# Altium schematic sheet Headlight_Right_Board.SchDoc
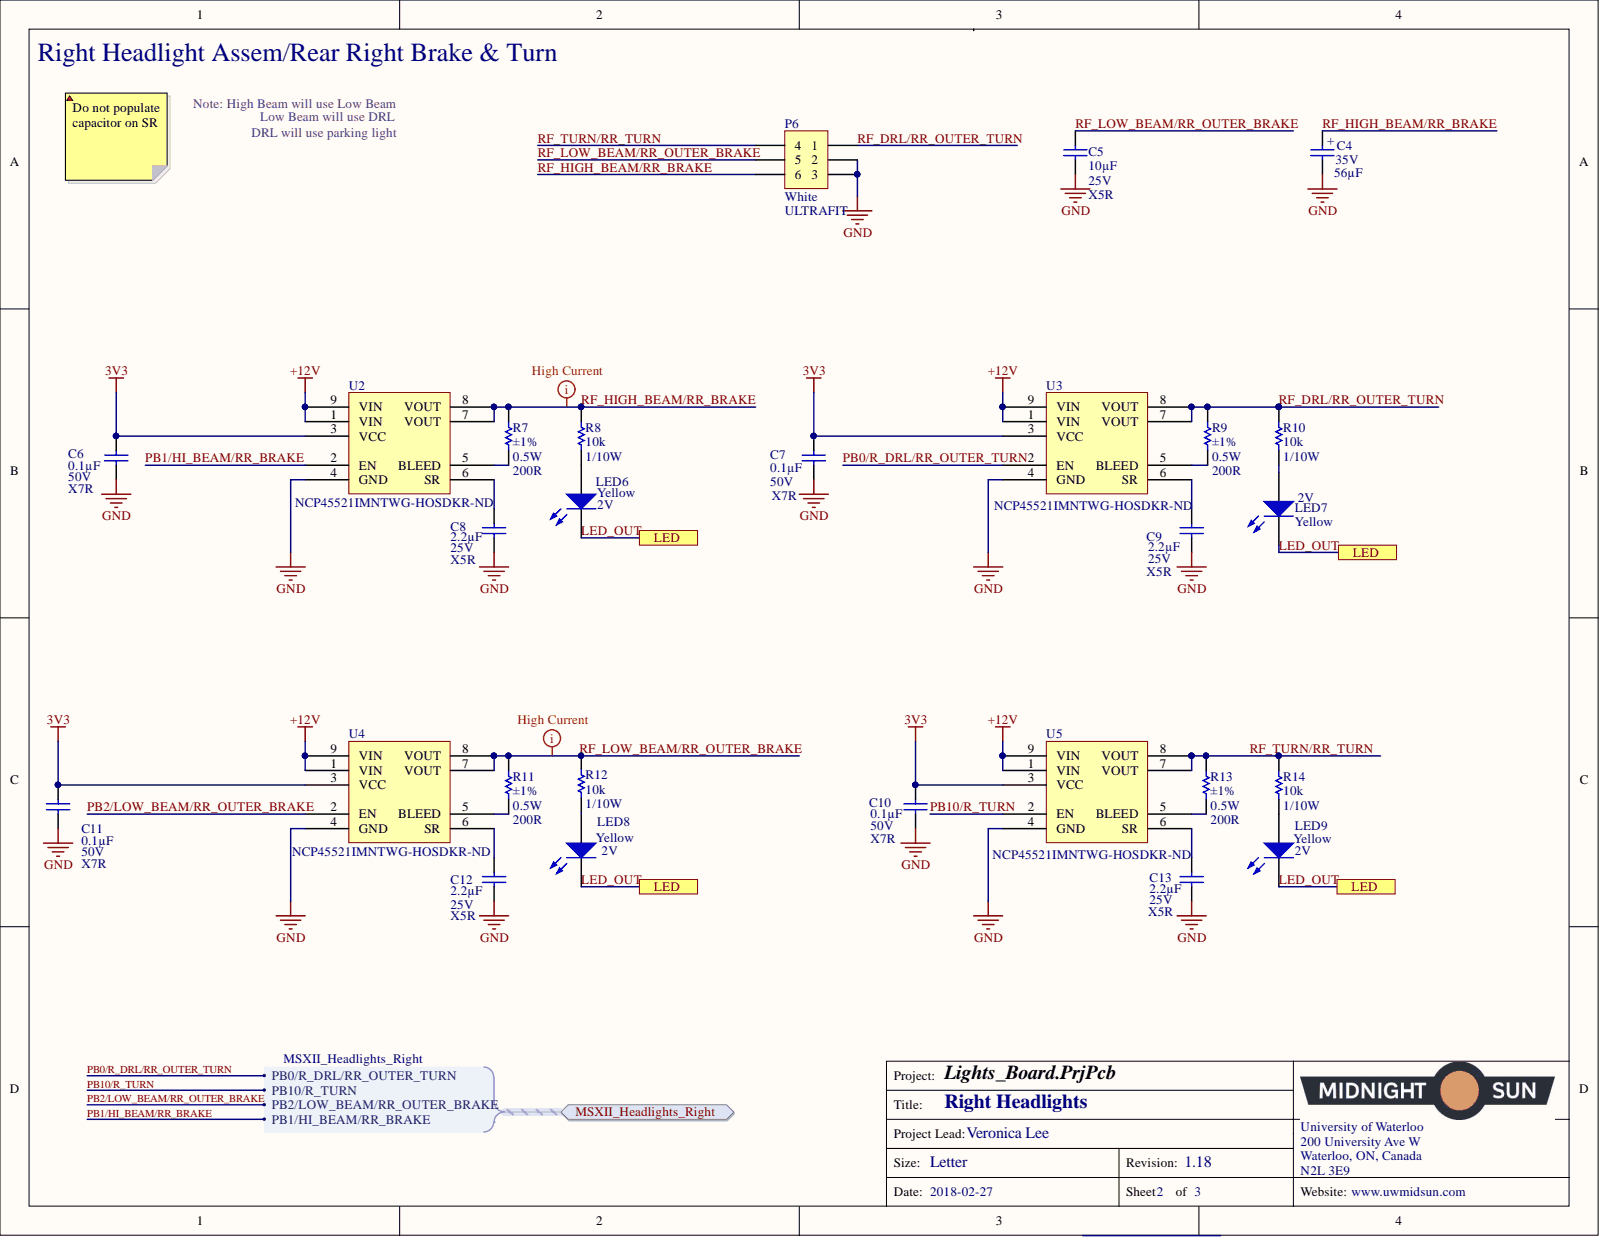
[diagram p0: Headlight_Right_Board.SchDoc]

## Parts
C4  Capacitance: 56µF  Voltage: 35V  [CAP ALUM 56UF 20% 35V RADIAL]  (hidden comment: CAP ALUM 56UF 20% 35V RADIAL)
C5  Capacitance: 10µF  Temperature Coefficient: X5R  Voltage: 25V  [CAP, 0603]  (hidden comment: CAP CER 10uF 25V 20% X5R 0603)
C6  Capacitance: 0.1µF  Temperature Coefficient: X7R  Voltage: 50V  [CAP, 0603]  (hidden comment: CAP CER 0.1UF 50V 10% X7R 0603)
C7  Capacitance: 0.1µF  Temperature Coefficient: X7R  Voltage: 50V  [CAP, 0603]  (hidden comment: CAP CER 0.1UF 50V 10% X7R 0603)
C8  Capacitance: 2.2µF  Temperature Coefficient: X5R  Voltage: 25V  [CAP, 0603]  (hidden comment: CAP CER 2.2UF 25V 10% X5R 0603)  (no BOM)
C9  Capacitance: 2.2µF  Temperature Coefficient: X5R  Voltage: 25V  [CAP, 0603]  (hidden comment: CAP CER 2.2UF 25V 10% X5R 0603)  (no BOM)
C10  Capacitance: 0.1µF  Temperature Coefficient: X7R  Voltage: 50V  [CAP, 0603]  (hidden comment: CAP CER 0.1UF 50V 10% X7R 0603)
C11  Capacitance: 0.1µF  Voltage: 50V  [CAP, 0603]  (hidden comment: CAP CER 0.1UF 50V 10% X7R 0603)
C12  Capacitance: 2.2µF  Temperature Coefficient: X5R  Voltage: 25V  [CAP, 0603]  (hidden comment: CAP CER 2.2UF 25V 10% X5R 0603)  (no BOM)
C13  Capacitance: 2.2µF  Temperature Coefficient: X5R  Voltage: 25V  [CAP, 0603]  (hidden comment: CAP CER 2.2UF 25V 10% X5R 0603)  (no BOM)
LED6  Color: Yellow  Voltage - Forward (Vf) (Typ): 2V  [LED_0603]  (hidden comment: LED YELLOW CLEAR 2.1V 0603)
LED7  Color: Yellow  Voltage - Forward (Vf) (Typ): 2V  [LED_0603]  (hidden comment: LED YELLOW CLEAR 2.1V 0603)
LED8  Color: Yellow  Voltage - Forward (Vf) (Typ): 2V  [LED_0603]  (hidden comment: LED YELLOW CLEAR 2.1V 0603)
LED9  Color: Yellow  Voltage - Forward (Vf) (Typ): 2V  [LED_0603]  (hidden comment: LED YELLOW CLEAR 2.1V 0603)
P6  Insulation Color: White  Series: ULTRAFIT  [CONN, 6POS ULTRA-FIT - NATURAL COLOR]  (hidden comment: CONN 6POS ULTRA-FIT NATURAL COLOR 0.138")
R7  value 200R  Power (Watts): 0.5W  Tolerance: ±1%  [RES 0805]  (hidden comment: RES SMD 200 OHM 1% 1/2W 0805)
R8  Power: 1/10W  Resistance: 10k  [RES, 0603]  (hidden comment: RES 10K OHM 1% 1/10W 0603)
R9  value 200R  Power (Watts): 0.5W  Tolerance: ±1%  [RES 0805]  (hidden comment: RES SMD 200 OHM 1% 1/2W 0805)
R10  Power: 1/10W  Resistance: 10k  [RES, 0603]  (hidden comment: RES 10K OHM 1% 1/10W 0603)
R11  value 200R  Power (Watts): 0.5W  Tolerance: ±1%  [RES 0805]  (hidden comment: RES SMD 200 OHM 1% 1/2W 0805)
R12  Power: 1/10W  Resistance: 10k  [RES, 0603]  (hidden comment: RES 10K OHM 1% 1/10W 0603)
R13  value 200R  Power (Watts): 0.5W  Tolerance: ±1%  [RES 0805]  (hidden comment: RES SMD 200 OHM 1% 1/2W 0805)
R14  Power: 1/10W  Resistance: 10k  [RES, 0603]  (hidden comment: RES 10K OHM 1% 1/10W 0603)
U2  IC LOAD SWITCH ACT-HI 10.5A 8DFN  [IC LOAD SWITCH ACT-HI 10.5A 8DFN]  (value not drawn)
U3  IC LOAD SWITCH ACT-HI 10.5A 8DFN  [IC LOAD SWITCH ACT-HI 10.5A 8DFN]  (value not drawn)
U4  IC LOAD SWITCH ACT-HI 10.5A 8DFN  [IC LOAD SWITCH ACT-HI 10.5A 8DFN]  (value not drawn)
U5  IC LOAD SWITCH ACT-HI 10.5A 8DFN  [IC LOAD SWITCH ACT-HI 10.5A 8DFN]  (value not drawn)

## Ports
LED, MSXII_Headlights_Right, MSXII_Headlights_Right.PB0/R_DRL/RR_OUTER_TURN, MSXII_Headlights_Right.PB1/HI_BEAM/RR_BRAKE, MSXII_Headlights_Right.PB2/LOW_BEAM/RR_OUTER_BRAKE, MSXII_Headlights_Right.PB10/R_TURN

## Nets
3V3: C6.1 C7.1 C10.1 C11.1 U2.3(VCC) U3.3(VCC) U4.3(VCC) U5.3(VCC)
+12V: U2.1(VIN) U2.9(VIN) U3.1(VIN) U3.9(VIN) U4.1(VIN) U4.9(VIN) U5.1(VIN) U5.9(VIN)
GND: C4.2 C5.1 C6.2 C7.2 C8.2 C9.2 C10.2 C11.2 C12.2 C13.2 P6.2 P6.3 U2.4(GND) U3.4(GND) U4.4(GND) U5.4(GND)
LED_OUT: LED6.2 LED7.2 LED8.2 LED9.2 ; port LED
PB0/R_DRL/RR_OUTER_TURN: U3.2(EN) ; port MSXII_Headlights_Right
PB1/HI_BEAM/RR_BRAKE: U2.2(EN) ; port MSXII_Headlights_Right
PB2/LOW_BEAM/RR_OUTER_BRAKE: U4.2(EN) ; port MSXII_Headlights_Right
PB10/R_TURN: U5.2(EN) ; port MSXII_Headlights_Right
RF_DRL/RR_OUTER_TURN: P6.1 R9.1 R10.1 U3.7(VOUT) U3.8(VOUT)
RF_HIGH_BEAM/RR_BRAKE: C4.1 P6.6 R7.2 R8.1 U2.7(VOUT) U2.8(VOUT)
RF_LOW_BEAM/RR_OUTER_BRAKE: C5.2 P6.5 R11.1 R12.1 U4.7(VOUT) U4.8(VOUT)
RF_TURN/RR_TURN: P6.4 R13.1 R14.1 U5.7(VOUT) U5.8(VOUT)
NetC8_1: C8.1 U2.6(SR)
NetC9_1: C9.1 U3.6(SR)
NetC12_1: C12.1 U4.6(SR)
NetC13_1: C13.1 U5.6(SR)
NetLED6_1: LED6.1 R8.2
NetLED7_1: LED7.1 R10.2
NetLED8_1: LED8.1 R12.2
NetLED9_1: LED9.1 R14.2
NetR7_1: R7.1 U2.5(BLEED)
NetR9_2: R9.2 U3.5(BLEED)
NetR11_2: R11.2 U4.5(BLEED)
NetR13_2: R13.2 U5.5(BLEED)
N00061:

## Library data of the parts
C4 = CAP ALUM 56UF 20% 35V RADIAL: 56µF 35V Aluminum Electrolytic Capacitors Radial, Can 1000 Hrs @ 105°C; Manufacturer: Panasonic Electronic Components; Manufacturer Part Number: EEU-FC1V560H; Polarization: Polar; Applications: Automotive; Category: Capacitors; Component Kind: Standard; ComponentLink1Description: http://industrial.panasonic.com/www-cgi/jvcr13pz.cgi?E+PZ+3+ABA0022+EEUFC1V560H+7+WW; ComponentLink1URL: http://industrial.panasonic.com/www-cgi/jvcr13pz.cgi?E+PZ+3+ABA0022+EEUFC1V560H+7+WW; ESR (Equivalent Series Resistance): -; Height - Seated (Max): 0.480" (12.20mm); Lead Spacing: 0.098" (2.50mm); Lifetime @ Temp.: 1000 Hrs @ 105°C; Mounting Type: Through Hole; Operating Temperature: -55°C ~ 105°C; Package / Case: Radial, Can; Packaging: Cut Tape (CT); Part Status: Active; Pricing 1: 1=0.49, 10=0.347, 100=0.2082, 500=0.15622, 1000=0.13193 (CAD); Ratings: AEC-Q200; RoHS: RoHS Compliant; Size / Dimension: 0.248" Dia (6.30mm); Stock 1: 21680; Supplier 1: Digi-Key; Supplier Part Number 1: P19684CT-ND; Surface Mount Land Size: -; Library Name: Schematic Diagrams.SchLib
C5 = CAP CER 10uF 25V 20% X5R 0603: 10µF ±20% 25V Ceramic Capacitor X5R 0603 (1608 Metric); Manufacturer 1: Murata Electronics North America; Manufacturer Part Number 1: GRM188R61E106MA73D; Component Kind: Standard; ComponentLink1Description: http://www.murata.com/~/media/webrenewal/support/library/catalog/products/capacitor/mlcc/c02e.ashx; ComponentLink1URL: http://www.murata.com/~/media/webrenewal/support/library/catalog/products/capacitor/mlcc/c02e.ashx; Height - Seated (Max): -; Lead Spacing: -; Lead Style: -; Mounting Type: Surface Mount, MLCC; Operating Temperature: -55°C ~ 85°C; Package / Case: 0603 (1608 Metric); Packaging: Cut Tape (CT); Part Status: Active; Ratings: -; Size / Dimension: 0.063" L x 0.031" W (1.60mm x 0.80mm); Supplier 1: Digi-Key; Supplier Part Number 1: 490-7202-1-ND; Thickness (Max): 0.031" (0.80mm); Tolerance: ±20%; Library Name: Schematic Diagrams.SchLib
C6 C7 C10 C11 = CAP CER 0.1UF 50V 10% X7R 0603: 0.10µF ±10% 50V Ceramic Capacitor X7R 0603 (1608 Metric); Manufacturer 1: AVX Corporation; Manufacturer Part Number 1: 06035C104KAT2A; Component Kind: Standard; ComponentLink1Description: http://datasheets.avx.com/X7RDielectric.pdf; ComponentLink1URL: http://datasheets.avx.com/X7RDielectric.pdf; Height - Seated (Max): -; Lead Spacing: -; Lead Style: -; Mounting Type: Surface Mount, MLCC; Operating Temperature: -55°C ~ 125°C; Package / Case: 0603 (1608 Metric); Packaging: Cut Tape (CT); Part Status: Active; Ratings: -; Size / Dimension: 0.063" L x 0.032" W (1.60mm x 0.81mm); Supplier 1: Digi-Key; Supplier Part Number 1: 478-5052-1-ND; Thickness (Max): 0.035" (0.90mm); Tolerance: ±10%; Library Name: Schematic Diagrams.SchLib
  C11: Temperature Coefficient: X7R
C8 C9 C12 C13 = CAP CER 2.2UF 25V 10% X5R 0603: 2.2µF ±10% 25V Ceramic Capacitor X5R 0603 (1608 Metric); Manufacturer 1: Murata Electronics North America; Manufacturer Part Number 1: GRM188R61E225KA12D; ComponentLink1Description: http://www.murata.com/~/media/webrenewal/support/library/catalog/products/capacitor/mlcc/c02e.ashx; ComponentLink1URL: http://www.murata.com/~/media/webrenewal/support/library/catalog/products/capacitor/mlcc/c02e.ashx; Height - Seated (Max): -; Lead Spacing: -; Lead Style: -; Mounting Type: Surface Mount, MLCC; Operating Temperature: -55°C ~ 85°C; Package / Case: 0603 (1608 Metric); Packaging: Cut Tape (CT); Part Status: Active; Ratings: -; Size / Dimension: 0.063" L x 0.031" W (1.60mm x 0.80mm); Supplier 1: Digi-Key; Supplier Part Number 1: 490-10731-1-ND; Thickness (Max): 0.035" (0.90mm); Tolerance: ±10%; Library Name: Schematic Diagrams.SchLib
LED6-LED9 = LED YELLOW CLEAR 2.1V 0603: Yellow 590nm LED Indication - Discrete 2V 0603 (1608 Metric); Manufacturer: Wurth Electronics Inc.; Manufacturer Part Number: 150060YS75000; Current - Test: 20mA; Lens Color: Colorless; Lens Style/Size: Rectangle with Flat Top, 1.10mm x 0.70mm; Millicandela Rating: 120mcd; Viewing Angle: 140°; Wavelength - Peak: 595nm; Category: Optoelectronics; Component Kind: Standard; ComponentLink1Description: http://katalog.we-online.de/led/datasheet/150060YS75000.pdf; ComponentLink1URL: http://katalog.we-online.de/led/datasheet/150060YS75000.pdf; Features: -; Height (Max): 0.80mm; Lens Transparency: Clear; Mounting Type: Surface Mount; Package / Case: 0603 (1608 Metric); Packaging: Cut Tape (CT); Part Status: Active; Pricing 1: 1=0.21, 100=0.1617, 500=0.13964 (CAD); RoHS: RoHS Compliant; Size / Dimension: 1.60mm L x 0.80mm W; Stock 1: 10523; Supplier 1: Digi-Key; Supplier Device Package: 0603; Supplier Part Number 1: 732-4981-1-ND; Wavelength - Dominant: 590nm; Library Name: Schematic Diagrams.SchLib
P6 = CONN 6POS ULTRA-FIT NATURAL COLOR 0.138": 6 Positions Header Connector 0.138" (3.50mm) Through Hole Tin; Manufacturer 1: Molex, LLC; Manufacturer Part Number 1: 1722992106; Contact Length - Post: 0.126" (3.20mm); Description 1: ULTRAFIT VERT TIN PLATED NAT; Mated Stacking Heights: 26.99mm; Material Flammability Rating: UL94 V-0; Number of Positions: 6; Number of Positions Loaded: All; Row Spacing - Mating: 0.181" (4.60mm); Shrouding: Shrouded - 4 Wall; Style: Board to Cable/Wire; Voltage Rating: 400V; Applications: Automotive, General Purpose, Industrial, Lighting, Medical, Military, Telecommunications; Category: Connectors, Interconnects; Component Kind: Standard; ComponentLink1Description: http://www.molex.com/pdm_docs/sd/1722992106_sd.pdf; ComponentLink1URL: http://www.molex.com/pdm_docs/sd/1722992106_sd.pdf; Connector Type: Header; Contact Finish - Mating: Tin; Contact Finish - Post: Tin; Contact Finish Thickness - Mating: 60µin (1.52µm); Contact Finish Thickness - Post: 60µin (1.52µm); Contact Length - Mating: -; Contact Material: Copper Alloy; Contact Shape: Square; Contact Type: Male Pin; Current Rating: -; Fastening Type: Latch Holder; Features: Board Guide, Solder Retention; Ingress Protection: -; Insulation Height: 0.465" (11.80mm); Insulation Material: Liquid Crystal Polymer (LCP); Mounting Type: Through Hole; Number of Rows: 2; Operating Temperature: -40°C ~ 105°C; Overall Contact Length: -; Packaging: Tray; Part Status: Active; Pitch - Mating: 0.138" (3.50mm); Pricing 1: 1=1.85, 10=1.617, 50=1.4586, 100=1.395, 250=1.26816, 500=1.14134, 1000=1.01453, 2500=0.91942, 5000=0.88771 (CAD); RoHS: RoHS Compliant; Stock 1: 315; Supplier 1: Digi-Key; Supplier Part Number 1: WM11799-ND; Termination: Solder; Library Name: Schematic Diagrams.SchLib
R7 R9 R11 R13 = RES SMD 200 OHM 1% 1/2W 0805: 200 Ohms ±1% 0.5W, 1/2W Chip Resistor 0805 (2012 Metric) Automotive AEC-Q200, Pulse Withstanding Thick Film; Manufacturer: Panasonic Electronic Components; Manufacturer Part Number: ERJ-P06F2000V; Temperature Coefficient: ±100ppm/°C; Category: Resistors; Component Kind: Standard; ComponentLink1Description: https://industrial.panasonic.com/cdbs/www-data/pdf/RDO0000/AOA0000C331.pdf; ComponentLink1URL: https://industrial.panasonic.com/cdbs/www-data/pdf/RDO0000/AOA0000C331.pdf; Composition: Thick Film; Failure Rate: -; Features: Automotive AEC-Q200, Pulse Withstanding; Height - Seated (Max): 0.028" (0.70mm); Operating Temperature: -55°C ~ 155°C; Package / Case: 0805 (2012 Metric); Packaging: Cut Tape (CT); Part Status: Active; Pricing 1: 1=0.27, 10=0.226, 100=0.0884, 1000=0.03699, 2500=0.0339 (CAD); RoHS: RoHS Compliant; Size / Dimension: 0.079" L x 0.049" W (2.00mm x 1.25mm); Stock 1: 46246; Supplier 1: Digi-Key; Supplier Device Package: 0805; Library Name: Schematic Diagrams.SchLib
R8 R10 R12 R14 = RES 10K OHM 1% 1/10W 0603: RES SMD 10K OHM 1% 1/10W 0603; Manufacturer 1: Yageo; Manufacturer Part Number 1: RC0603FR-0710KL; Temperature Coefficient: ±100ppm/°C; Component Kind: Standard; ComponentLink1Description: http://www.yageo.com/documents/recent/PYu-RC_Group_51_RoHS_L_7.pdf; ComponentLink1URL: http://www.yageo.com/documents/recent/PYu-RC_Group_51_RoHS_L_7.pdf; Composition: Thick Film; Failure Rate: -; Height - Seated (Max): 0.022" (0.55mm); Operating Temperature: -55°C ~ 155°C; Package / Case: 0603 (1608 Metric); Packaging: Cut Tape (CT); Part Status: Active; Size / Dimension: 0.063" L x 0.031" W (1.60mm x 0.80mm); Supplier 1: Digi-Key; Supplier Device Package: 0603; Supplier Part Number 1: 311-10.0KHRCT-ND; Tolerance: ±1%; Library Name: Schematic Diagrams.SchLib
U2-U5 = IC LOAD SWITCH ACT-HI 10.5A 8DFN: NCP45521IMNTWG-H; Manufacturer: ON Semiconductor; Fault Protection: Over Temperature, UVLO; Input Type: Non-Inverting; Interface: On/Off; Number of Outputs: 1; Output Configuration: High Side; Output Type: N-Channel; Ratio - Input: 1:1; Rds On (Typ): 12.8 mOhm; Switch Type: General Purpose; Voltage - Load: 0.5 V ~ 13.5 V; Voltage - Supply (Vcc/Vdd): 3 V ~ 5.5 V; Category: Integrated Circuits (ICs); Component Kind: Standard; ComponentLink1Description: http://www.onsemi.com/pub/Collateral/NCP45520-D.PDF; ComponentLink1URL: http://www.onsemi.com/pub/Collateral/NCP45520-D.PDF; Current - Output (Max): 10.5A; Features: Slew Rate Controlled; Manufacturer Part Number: NCP45521IMNTWG-H; Operating Temperature: -40°C ~ 85°C (TA); Package / Case: 8-VFDFN Exposed Pad; Packaging: Digi-Reel®; Part Status: Active; Pricing 1: 1=1.57, 10=1.405, 100=1.0957, 500=0.90518, 1000=0.71462 (CAD); Pricing 2: 1=1.53, 10=1.372, 100=1.0702, 500=0.88408, 1000=0.69797 (CAD); RoHS: RoHS Compliant; Stock 1: 2377; Stock 2: 2211; Supplier 1: Digi-Key; Supplier 2: Digi-Key; Supplier Device Package: 8-DFN (2x2); Supplier Part Number 2: NCP45521IMNTWG-HOSDKR-ND; Library Name: Schematic Diagrams.SchLib
